# Altium schematic sheet AMC_SATA_Adapter.SchDoc(AMC_SATA_Adapter)
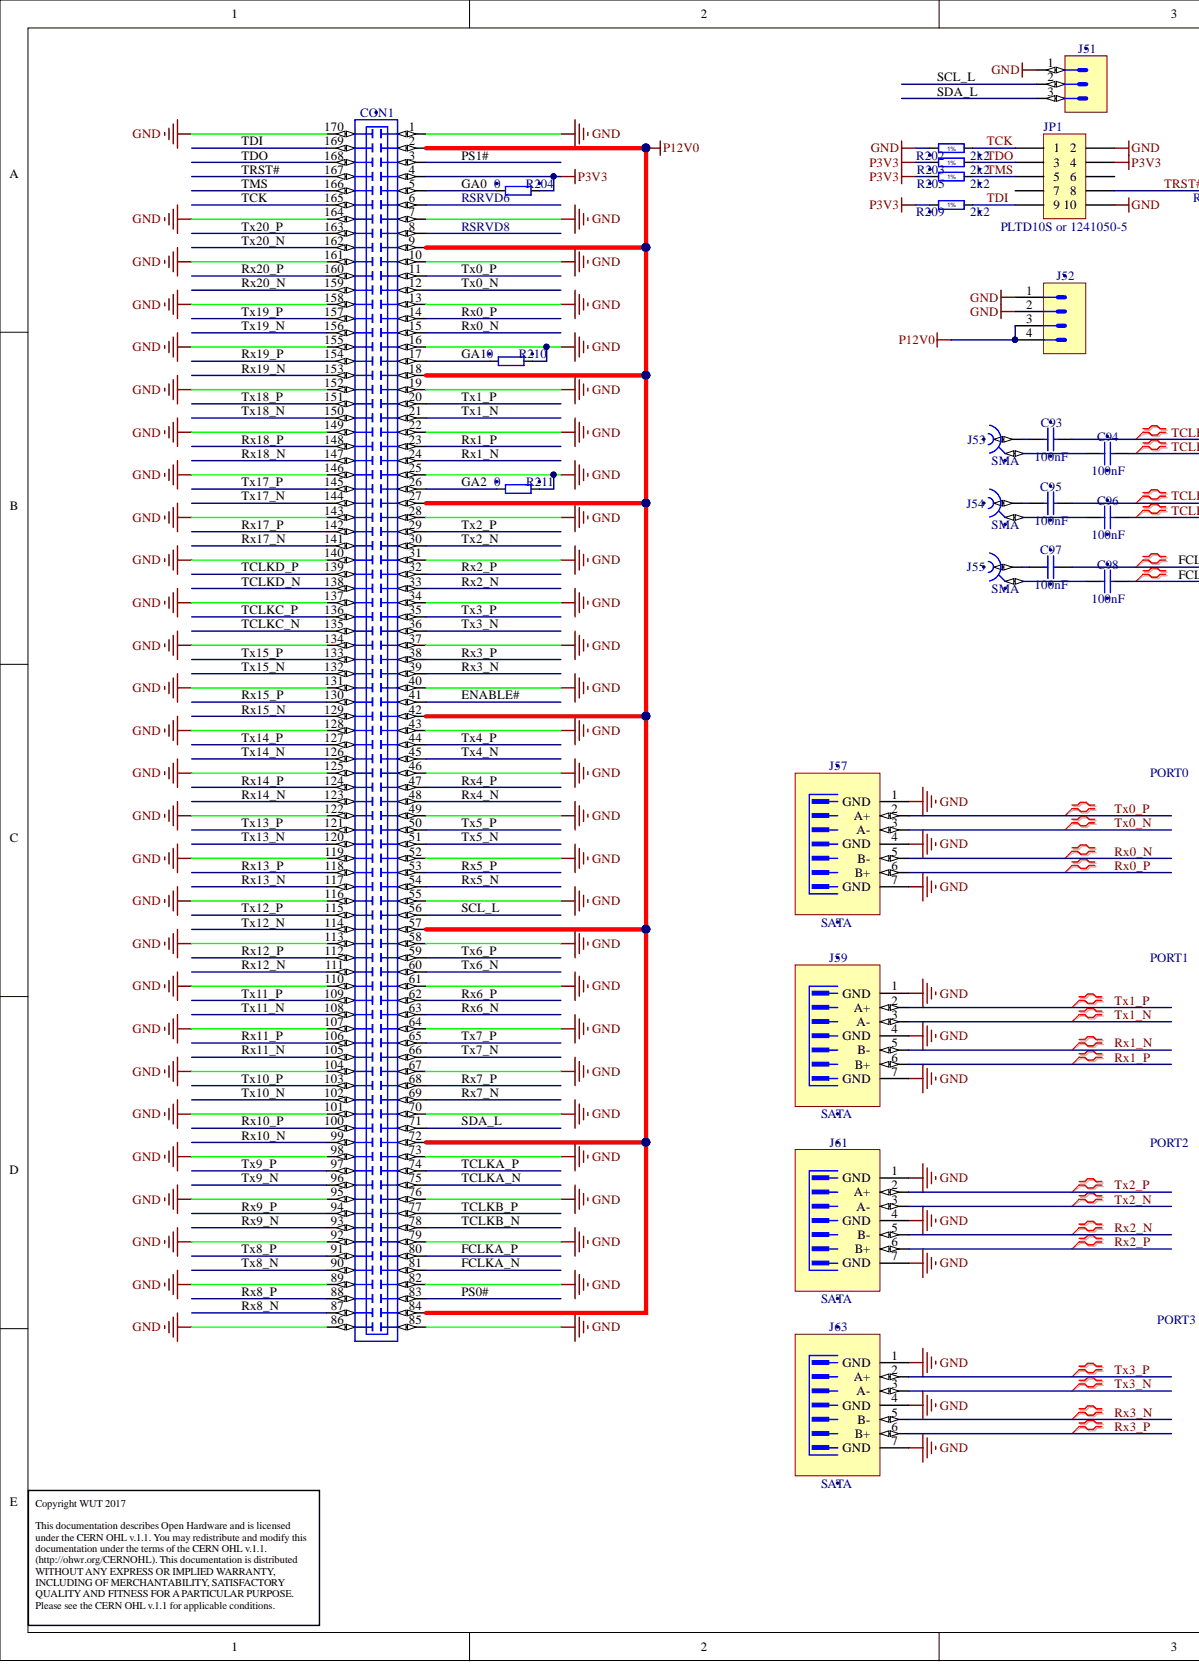
[diagram p0: AMC_SATA_Adapter.SchDoc, left]
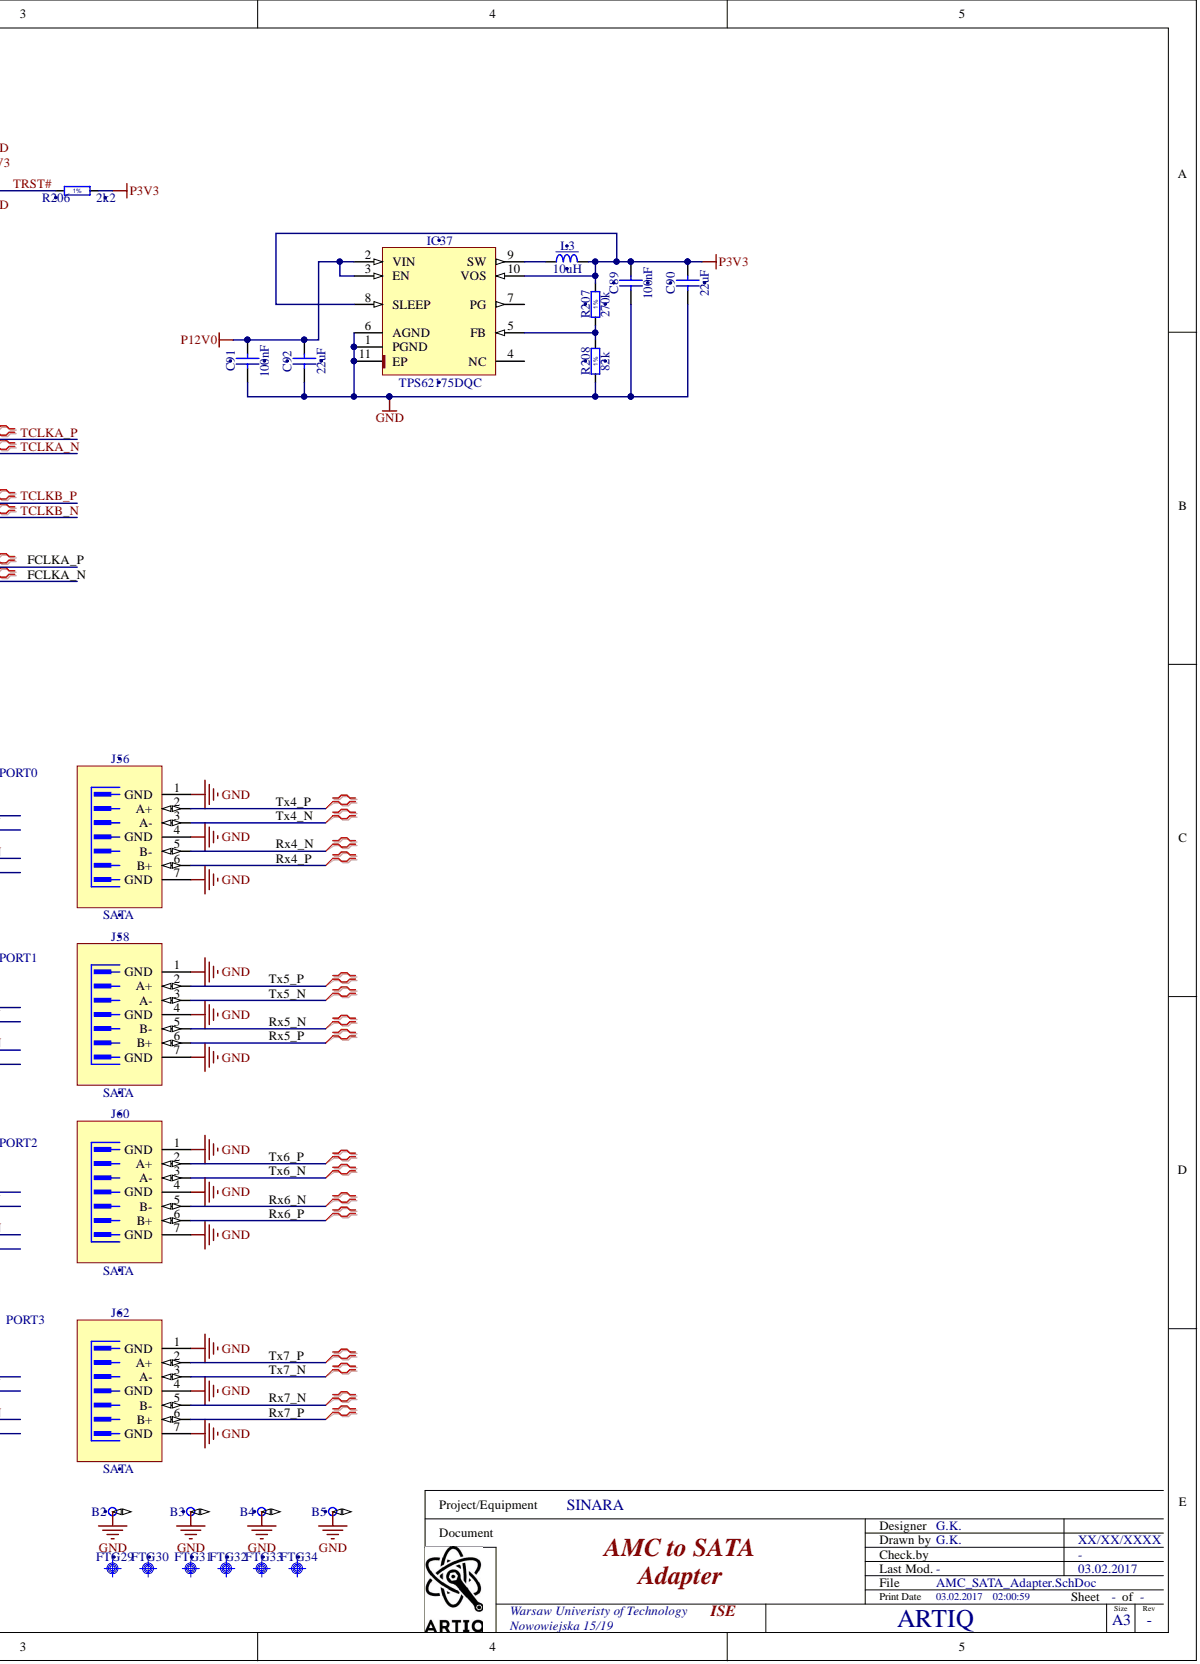
[diagram p1: AMC_SATA_Adapter.SchDoc, right]

## Parts
B2  Family  [MTG270_500]  (value not drawn)
B3  Family  [MTG270_500]  (value not drawn)
B4  Family  [MTG270_500]  (value not drawn)
B5  Family  [MTG270_500]  (value not drawn)
C89  100nF  [CAPC1005X55N]
C90  22uF  [CAPC2012X135N]
C91  100nF  [CAPC1005X55N]
C92  22uF  [CAPC2012X135N]
C93  100nF  [CAPC0603X33N]
C94  100nF  [CAPC0603X33N]
C95  100nF  [CAPC0603X33N]
C96  100nF  [CAPC0603X33N]
C97  100nF  [CAPC0603X33N]
C98  100nF  [CAPC0603X33N]
CON1  SAMTEC_MTCA-085-02-S-DV-SMT-K-TR  [SAMTEC_MTCA-085-02-S-DV-SMT-K-TR]  (value not drawn)
FTG29  Use only in PCB  (value not drawn)
FTG30  Use only in PCB  (value not drawn)
FTG31  Use only in PCB  (value not drawn)
FTG32  Use only in PCB  (value not drawn)
FTG33  Use only in PCB  (value not drawn)
FTG34  Use only in PCB  (value not drawn)
IC37  TPS62175DQC  [SON50P200X300X80-11N-R94X250]
J51  Header 3  [HARWIN_M22-2010305]  (value not drawn)
J52  Mini-Fit Jr.™  [MOLEX_39-28-1043]  (value not drawn)
J53  SMA  [HUBER-SUHNER_82_SMA-50-0-41/133_NE]
J54  SMA  [HUBER-SUHNER_82_SMA-50-0-41/133_NE]
J55  SMA  [HUBER-SUHNER_82_SMA-50-0-41/133_NE]
J56  SATA  [MOLEX_67800-5005]
J57  SATA  [MOLEX_67800-5005]
J58  SATA  [MOLEX_67800-5005]
J59  SATA  [MOLEX_67800-5005]
J60  SATA  [MOLEX_67800-5005]
J61  SATA  [MOLEX_67800-5005]
J62  SATA  [MOLEX_67800-5005]
J63  SATA  [MOLEX_67800-5005]
JP1  PLTD10S or 1241050-5  [PLTD10S]
L3  10uH  [IND_MURATA-PS_8400]
R202  2k2  [RESC1005X40N]
R203  2k2  [RESC1005X40N]
R204  0  [RESC1005X40N]
R205  2k2  [RESC1005X40N]
R206  2k2  [RESC1005X40N]
R207  270k  [RESC1608X55N]
R208  82k  [RESC1608X55N]
R209  2k2  [RESC1005X40N]
R210  0  [RESC1005X40N]
R211  0  [RESC1005X40N]

## Nets
GND: B2.1 B3.1 B4.1 B5.1 C89.1 C90.1 C91.1 C92.1 CON1.1 CON1.7 CON1.10 CON1.13 CON1.16 CON1.19 CON1.22 CON1.25 CON1.28 CON1.31 CON1.34 CON1.37 CON1.40 CON1.43 CON1.46 CON1.49 CON1.52 CON1.55 CON1.58 CON1.61 CON1.64 CON1.67 CON1.70 CON1.73 CON1.76 CON1.79 CON1.82 CON1.85 CON1.86 CON1.89 CON1.92 CON1.95 CON1.98 CON1.101 CON1.104 CON1.107 CON1.110 CON1.113 CON1.116 CON1.119 CON1.122 CON1.125 CON1.128 CON1.131 CON1.134 CON1.137 CON1.140 CON1.143 CON1.146 CON1.149 CON1.152 CON1.155 CON1.158 CON1.161 CON1.164 CON1.170 IC37.1(PGND) IC37.6(AGND) IC37.11(EP) J51.1 J52.1 J52.2 J56.1(GND) J56.4(GND) J56.7(GND) J57.1(GND) J57.4(GND) J57.7(GND) J58.1(GND) J58.4(GND) J58.7(GND) J59.1(GND) J59.4(GND) J59.7(GND) J60.1(GND) J60.4(GND) J60.7(GND) J61.1(GND) J61.4(GND) J61.7(GND) J62.1(GND) J62.4(GND) J62.7(GND) J63.1(GND) J63.4(GND) J63.7(GND) JP1.2 JP1.10 R202.2 R208.1 R210.2 R211.2
P3V3: C89.2 C90.2 CON1.4 IC37.8(SLEEP) IC37.10(VOS) JP1.4 L3.2 R203.2 R204.2 R205.2 R206.1 R207.2 R209.2
P12V0: C91.2 C92.2 CON1.2 CON1.9 CON1.18 CON1.27 CON1.42 CON1.57 CON1.72 CON1.84 IC37.2(VIN) IC37.3(EN) J52.3 J52.4
ENABLE#: CON1.41
FCLKA_N: C98.2 CON1.81
FCLKA_P: C97.2 CON1.80
GA0: CON1.5 R204.1
GA1: CON1.17 R210.1
GA2: CON1.26 R211.1
PS0#: CON1.83
PS1#: CON1.3
RX0_N: CON1.15 J57.5(B-)
RX0_P: CON1.14 J57.6(B+)
RX1_N: CON1.24 J59.5(B-)
RX1_P: CON1.23 J59.6(B+)
RX2_N: CON1.33 J61.5(B-)
RX2_P: CON1.32 J61.6(B+)
RX3_N: CON1.39 J63.5(B-)
RX3_P: CON1.38 J63.6(B+)
RX4_N: CON1.48 J56.5(B-)
RX4_P: CON1.47 J56.6(B+)
RX5_N: CON1.54 J58.5(B-)
RX5_P: CON1.53 J58.6(B+)
RX6_N: CON1.63 J60.5(B-)
RX6_P: CON1.62 J60.6(B+)
RX7_N: CON1.69 J62.5(B-)
RX7_P: CON1.68 J62.6(B+)
RX8_N: CON1.87
RX8_P: CON1.88
RX9_N: CON1.93
RX9_P: CON1.94
RX10_N: CON1.99
RX10_P: CON1.100
RX11_N: CON1.105
RX11_P: CON1.106
RX12_N: CON1.111
RX12_P: CON1.112
RX13_N: CON1.117
RX13_P: CON1.118
RX14_N: CON1.123
RX14_P: CON1.124
RX15_N: CON1.129
RX15_P: CON1.130
RX17_N: CON1.141
RX17_P: CON1.142
RX18_N: CON1.147
RX18_P: CON1.148
RX19_N: CON1.153
RX19_P: CON1.154
RX20_N: CON1.159
RX20_P: CON1.160
SCL_L: CON1.56 J51.2
SDA_L: CON1.71 J51.3
TCK: CON1.165 JP1.1 R202.1
TCLKA_N: C94.2 CON1.75
TCLKA_P: C93.2 CON1.74
TCLKB_N: C96.2 CON1.78
TCLKB_P: C95.2 CON1.77
TCLKC_N: CON1.135
TCLKC_P: CON1.136
TCLKD_N: CON1.138
TCLKD_P: CON1.139
TDI: CON1.169 JP1.9 R209.1
TDO: CON1.168 JP1.3 R203.1
TMS: CON1.166 JP1.5 R205.1
TRST#: CON1.167 JP1.8 R206.2
TX0_N: CON1.12 J57.3(A-)
TX0_P: CON1.11 J57.2(A+)
TX1_N: CON1.21 J59.3(A-)
TX1_P: CON1.20 J59.2(A+)
TX2_N: CON1.30 J61.3(A-)
TX2_P: CON1.29 J61.2(A+)
TX3_N: CON1.36 J63.3(A-)
TX3_P: CON1.35 J63.2(A+)
TX4_N: CON1.45 J56.3(A-)
TX4_P: CON1.44 J56.2(A+)
TX5_N: CON1.51 J58.3(A-)
TX5_P: CON1.50 J58.2(A+)
TX6_N: CON1.60 J60.3(A-)
TX6_P: CON1.59 J60.2(A+)
TX7_N: CON1.66 J62.3(A-)
TX7_P: CON1.65 J62.2(A+)
TX8_N: CON1.90
TX8_P: CON1.91
TX9_N: CON1.96
TX9_P: CON1.97
TX10_N: CON1.102
TX10_P: CON1.103
TX11_N: CON1.108
TX11_P: CON1.109
TX12_N: CON1.114
TX12_P: CON1.115
TX13_N: CON1.120
TX13_P: CON1.121
TX14_N: CON1.126
TX14_P: CON1.127
TX15_N: CON1.132
TX15_P: CON1.133
TX17_N: CON1.144
TX17_P: CON1.145
TX18_N: CON1.150
TX18_P: CON1.151
TX19_N: CON1.156
TX19_P: CON1.157
TX20_N: CON1.162
TX20_P: CON1.163
NetC93_1: C93.1 J53.1
NetC94_1: C94.1 J53.2
NetC95_1: C95.1 J54.1
NetC96_1: C96.1 J54.2
NetC97_1: C97.1 J55.1
NetC98_1: C98.1 J55.2
NetIC37_5: IC37.5(FB) R207.1 R208.2
NetIC37_9: IC37.9(SW) L3.1

Not connected: CON1 6 8; IC37 4(NC) 7(PG); JP1 6 7

## Library data of the parts
B2-B5 = Through Hole Pad: Component Kind: Standard; Description: Through Hole Pad; Library Name: Pads.SchLib
C89 C91 = CC0402_100NF_16V_10%_X5R: Non-polarised capacitor; Manufacturer: GENERIC; Manufacturer1 Part Number: GRM155R61C104KA88D; Part Description: SMD Multilayer Chip Ceramic Capacitor; Database Table Name: Capacitors SMD; TC: X5R; Tolerance: ±10%; Voltage: 16V; Case: 0402; Component Kind: Standard; Component Type: Standard; CreateDate: 10.12.2013; HelpURL: \\cern.ch\dfs\Applications\Altium\Datasheets\CC0402_X5R_MURATA_GRM.pdf; LatestRevisionDate: 10.12.2013; Library Name: Capacitors.DbLib; Manufacturer1 ComponentHeight: 0.55mm; Manufacturer1 Example: MURATA; Manufacturer Part Number: CC0402_100NF_16V_10%_X5R; Mounted: Yes; Part Number: CC0402_100NF_16V_10%_X5R; Pin Count: 2; Sense: No; SMD: Yes; Socket: No; Status: None; Value: 100nF; Author: CERN DEM JLC
C90 C92 = CC0805_22UF_16V_10%_X5R: Non-polarised capacitor; Manufacturer: GENERIC; Manufacturer1 Part Number: C2012X5R1C226KT; Part Description: SMD Multilayer Chip Ceramic Capacitor; Database Table Name: Capacitors SMD; TC: X5R; Tolerance: ±10%; Voltage: 16V; Case: 0805; Component Kind: Standard; Component Type: Standard; CreateDate: 25.10.2012; HelpURL: \\cern.ch\dfs\Applications\Altium\Datasheets\CC0805_X5R_TDK_C.pdf; LatestRevisionDate: 25.10.2012; Library Name: Capacitors.DbLib; Manufacturer1 ComponentHeight: 1.35mm; Manufacturer1 Example: TDK; Manufacturer Part Number: CC0805_22UF_16V_10%_X5R; Mounted: Yes; Part Number: CC0805_22UF_16V_10%_X5R; Pin Count: 2; Sense: No; SMD: Yes; Socket: No; Status: Preferred; Value: 22uF; Author: CERN DEM JLC
C93-C98 = CC0201_100NF_6.3V_10%_X5R: Non-polarised capacitor; Manufacturer: GENERIC; Manufacturer1 Part Number: 02016D104KAT2A; Part Description: SMD Multilayer Chip Ceramic Capacitor; Database Table Name: Capacitors SMD; TC: X5R; Tolerance: ±10%; Voltage: 6.3V; Case: 0201; Component Kind: Standard; Component Type: Standard; CreateDate: 03.12.2007; HelpURL: \\cern.ch\dfs\Applications\Altium\Datasheets\CC0201_X5R_AVX.pdf; LatestRevisionDate: 03.12.2007; Library Name: Capacitors.DbLib; Manufacturer1 ComponentHeight: 0.33mm; Manufacturer1 Example: AVX; Manufacturer Part Number: CC0201_100NF_6.3V_10%_X5R; Mounted: Yes; Part Number: CC0201_100NF_6.3V_10%_X5R; Pin Count: 2; Sense: No; SMD: Yes; Socket: No; Status: Not Recommended; Value: 100nF; Author: CERN DEM JLC
CON1 = SAMTEC_MTCA-085-02-S-DV-SMT-K-TR: 170 Poles,  Micro TCA (Telecom Computing Architecture) Edge Card Socket; Part Description: 170 Poles, Pitch 0.75mm, Micro TCA (Telecom Computing Architecture) Edge Card Socket; Database Table Name: SAMTEC; ComponentHeight: 12.85mm; Component Kind: Standard; Component Type: Standard; CreateDate: 2012-08-15; HelpURL: \\cern.ch\dfs\Applications\Altium\Datasheets\SAMTEC_MTCA-085-02-S-DV-SMT-K-TR.pdf; LatestRevisionDate: 2012-08-15; Library Name: Connectors.DbLib; Manufacturer: SAMTEC; Manufacturer Part Number: MTCA-085-02-S-DV-SMT-K-TR; Mounted: Yes; Part Number: SAMTEC_MTCA-085-02-S-DV-SMT-K-TR; Pin Count: 170; SMD: Yes; Socket: No; Status: None; Table: SAMTEC; Author: CERN DEM JLC
FTG29-FTG34 = Fiducial Target: Component Kind: Standard; Description: Fiducial Target; Library Name: Pads.SchLib
IC37 = TPS62175DQC: 4.75-28VDC to 1-6VDC, 500mA Step-Down Converter With Sleep Mode; Manufacturer: TEXAS INSTRUMENTS; Bonding: No; Database Table Name: Regulators; PressFit: No; Case: SON10; ComponentHeight: 0.8mm; Component Kind: Standard; Component Type: Standard; CreateDate: 11.08.2015; Device: TPS62175DQC; HelpURL: \\cern.ch\dfs\Applications\Altium\Datasheets\TPS62175DQC.pdf; LatestRevisionDate: 11.08.2015; Library Name: ICs And Semiconductors.DbLib; Manufacturer Part Number: TPS62175DQC; Mounted: Yes; PackageDescription: SON, 10-Leads, Body 2x3mm, Pitch 0.5mm, Thermal Pad 0.94x2.5mm, IPC Medium Density; Part Description: 4.75-28VDC to 1-6VDC, 500mA Step-Down Converter With Sleep Mode; Part Number: TPS62175DQC; Pin Count: 11; Sense: No; SMD: Yes; Socket: No; Author: CERN DEM JMW
J51 = HARWIN_M22-2010305: Connector 3 Male; Part Description: 3 Contacts - Pitch 2mm - Single Row Through Mount Straight Header (Post Lead = 3.5mm, Tail Lead 3.4mm, Insulator 1.5mm); Database Table Name: HARWIN; PressFit: No; ComponentHeight: 5mm; Component Kind: Standard; Component Type: Standard; CreateDate: 14.04.2015; Family: Header 3; HelpURL: \\cern.ch\dfs\Applications\Altium\Datasheets\HARWIN_M22-2010305.pdf; LatestRevisionDate: 14.04.2015; Library Name: Connectors.DbLib; Manufacturer: HARWIN; Manufacturer Part Number: M22-2010305; Mounted: Yes; Part Number: HARWIN_M22-2010305; Pin Count: 3; Sense: No; SMD: No; Socket: No; Status: None; Author: CERN DEM JLC
J52 = MOLEX_39-28-1043: Connector 4 Male; Part Description: 4.20mm (.165") Pitch Mini-Fit Jr.™ Header, Dual Row, Vertical, without Snap-in Plastic Peg PCB Lock, 4 Circuits; Database Table Name: MOLEX; ComponentHeight: 13.1mm; Component Kind: Standard; Component Type: Standard; CreateDate: 2009-03-12; Family: Mini-Fit Jr.™; HelpURL: \\cern.ch\dfs\Applications\Altium\Datasheets\MOLEX_39-28-1043.pdf; LatestRevisionDate: 2012-03-06; Library Name: Connectors.DbLib; Manufacturer: MOLEX; Manufacturer Part Number: 39-28-1043; Mounted: Yes; Part Number: MOLEX_39-28-1043; Pin Count: 4; SMD: No; Socket: No; Status: None; Table: MOLEX; Author: CERN DEM JLC
J53-J55 = HUBER-SUHNER_82_SMA-50-0-41/133_NE: Manufacturer: HUBER+SUHNER; Part Description: SMA 50 Ohms Straight PCB Jack; Database Table Name: Miscellaneous; PressFit: No; ComponentHeight: 9.6mm; Component Kind: Standard; Component Type: Standard; CreateDate: 20.04.2010; Family: SMA; HelpURL: \\cern.ch\dfs\Applications\Altium\Datasheets\HUBER-SUHNER_82_SMA-50-0-41-133_NE.pdf; LatestRevisionDate: 06.11.2014; Library Name: Connectors.DbLib; Manufacturer Part Number: 82_SMA-50-0-41/133_NE; Mounted: Yes; Part Number: HUBER-SUHNER_82_SMA-50-0-41/133_NE; Pin Count: 2; Sense: No; SMD: No; Socket: No; Status: None; Author: CERN DEM JLC
J56-J63 = MOLEX_67800-5005: 7 Pin SATA Receptacle; Part Description: 1.27mm Pitch, 7 Contacts, Serial ATA High Speed Header, Straight with Locking Latch; Database Table Name: MOLEX; PressFit: No; ComponentHeight: 8.4mm; Component Kind: Standard; Component Type: Standard; CreateDate: 01.12.2016; Family: SATA; HelpURL: \\cern.ch\dfs\Applications\Altium\Datasheets\MOLEX_67800-5005.pdf; LatestRevisionDate: 01.12.2016; Library Name: Connectors.DbLib; Manufacturer: MOLEX; Manufacturer Part Number: 67800-5005; Mounted: Yes; Part Number: MOLEX_67800-5005; Pin Count: 7; Sense: No; SMD: Yes; Socket: No; Status: None; Author: CERN DEM MR
JP1 = CON_2x5 2.54 male SMT: Goldpin 2.54mm, male header; Component Kind: Standard; Library Name: LIBRARY_SCH.SCHLIB
L3 = IND_10UH_10%_MURATA-PS_8400: Inductor With Core; Manufacturer: MURATA POWER SOLUTIONS; Manufacturer Part Number: 84103C; Part Description: Miniature Surface Mount Power Inductor (8400 Serie); Database Table Name: Inductors SMD; Power: 950mA; Resistance: 0.24R; Tolerance: ±10%; ComponentHeight: 2.9mm; Component Kind: Standard; Component Type: Standard; CreateDate: 02.07.2012; HelpURL: \\cern.ch\dfs\Applications\Altium\Datasheets\IND_MURATA-PS_8400.pdf; LatestRevisionDate: 02.07.2012; Library Name: Inductors & Transformers.DbLib; Mounted: Yes; Part Number: IND_10UH_10%_MURATA-PS_8400; Pin Count: 2; Sense: No; SMD: Yes; Socket: No; Status: None; Value: 10uH; Author: CERN DEM JLC
R202 R203 R205 R206 R209 = R0402_2K2_1%_0.0625W_100PPM: Resistor - 1%; Manufacturer: GENERIC; Manufacturer1 Part Number: 232270672202L; Part Description: General Purpose Thick Film Chip Resistor; Database Table Name: Resistors SMD; TC: ±100ppm/°C; Case: 0402; Component Kind: Standard; Component Type: Standard; CreateDate: 03.12.2007; HelpURL: \\cern.ch\dfs\Applications\Altium\Datasheets\R0402_Phycomp_RC0402.pdf; LatestRevisionDate: 17.10.2012; Library Name: Resistors.DbLib; Manufacturer1 ComponentHeight: 0.4mm; Manufacturer1 Example: YAGEO PHYCOMP; Manufacturer Part Number: R0402_2K2_1%_0.0625W_100PPM; Mounted: Yes; Part Number: R0402_2K2_1%_0.0625W_100PPM; Pin Count: 2; Power: 0.0625W; Sense: No; SMD: Yes; Socket: No; Status: Not recommended; Tolerance: ±1%; Value: 2k2; Author: CERN DEM JLC
R204 R210 R211 = R0402_0R_JUMPER: Resistor; Manufacturer: GENERIC; Manufacturer1 Part Number: 232270591001L; Part Description: 1A (0.05R Max DC Resistance) Zero Ohm Jumper; Database Table Name: Resistors SMD; Case: 0402; Component Kind: Standard; Component Type: Standard; CreateDate: 03.12.2007; HelpURL: \\cern.ch\dfs\Applications\Altium\Datasheets\R0402_Phycomp_RC0402.pdf; LatestRevisionDate: 17.10.2012; Library Name: Resistors.DbLib; Manufacturer1 ComponentHeight: 0.4mm; Manufacturer1 Example: YAGEO PHYCOMP; Manufacturer Part Number: R0402_0R_JUMPER; Mounted: Yes; Part Number: R0402_0R_JUMPER; Pin Count: 2; Sense: No; SMD: Yes; Socket: No; Status: Not recommended; Value: 0; Author: CERN DEM JLC
R207 = R0603_270K_1%_0.1W_100PPM: Resistor - 1%; Manufacturer: GENERIC; Manufacturer1 Part Number: NRC06F2703TRF; Part Description: General Purpose Thick Film Chip Resistor; Database Table Name: Resistors SMD; Power: 0.1W; TC: ±100ppm/°C; Case: 0603; Component Kind: Standard; Component Type: Standard; CreateDate: 03.12.2007; HelpURL: \\cern.ch\dfs\Applications\Altium\Datasheets\R0603_NIC_NRC.pdf; LatestRevisionDate: 13.03.2008; Library Name: Resistors.DbLib; Manufacturer1 ComponentHeight: 0.55mm; Manufacturer1 Example: NIC COMPONENT; Manufacturer Part Number: R0603_270K_1%_0.1W_100PPM; Mounted: Yes; Part Number: R0603_270K_1%_0.1W_100PPM; Pin Count: 2; Sense: No; SMD: Yes; Socket: No; Status: Preferred; Tolerance: ±1%; Value: 270k; Author: CERN DEM JLC
R208 = R0603_82K_1%_0.1W_100PPM: Resistor - 1%; Manufacturer: GENERIC; Manufacturer1 Part Number: NRC06F8202TRF; Part Description: General Purpose Thick Film Chip Resistor; Database Table Name: Resistors SMD; Power: 0.1W; TC: ±100ppm/°C; Case: 0603; Component Kind: Standard; Component Type: Standard; CreateDate: 03.12.2007; HelpURL: \\cern.ch\dfs\Applications\Altium\Datasheets\R0603_NIC_NRC.pdf; LatestRevisionDate: 13.03.2008; Library Name: Resistors.DbLib; Manufacturer1 ComponentHeight: 0.55mm; Manufacturer1 Example: NIC COMPONENT; Manufacturer Part Number: R0603_82K_1%_0.1W_100PPM; Mounted: Yes; Part Number: R0603_82K_1%_0.1W_100PPM; Pin Count: 2; Sense: No; SMD: Yes; Socket: No; Status: Preferred; Tolerance: ±1%; Value: 82k; Author: CERN DEM JLC
